# Altium schematic sheet IO.SchDoc(IO)
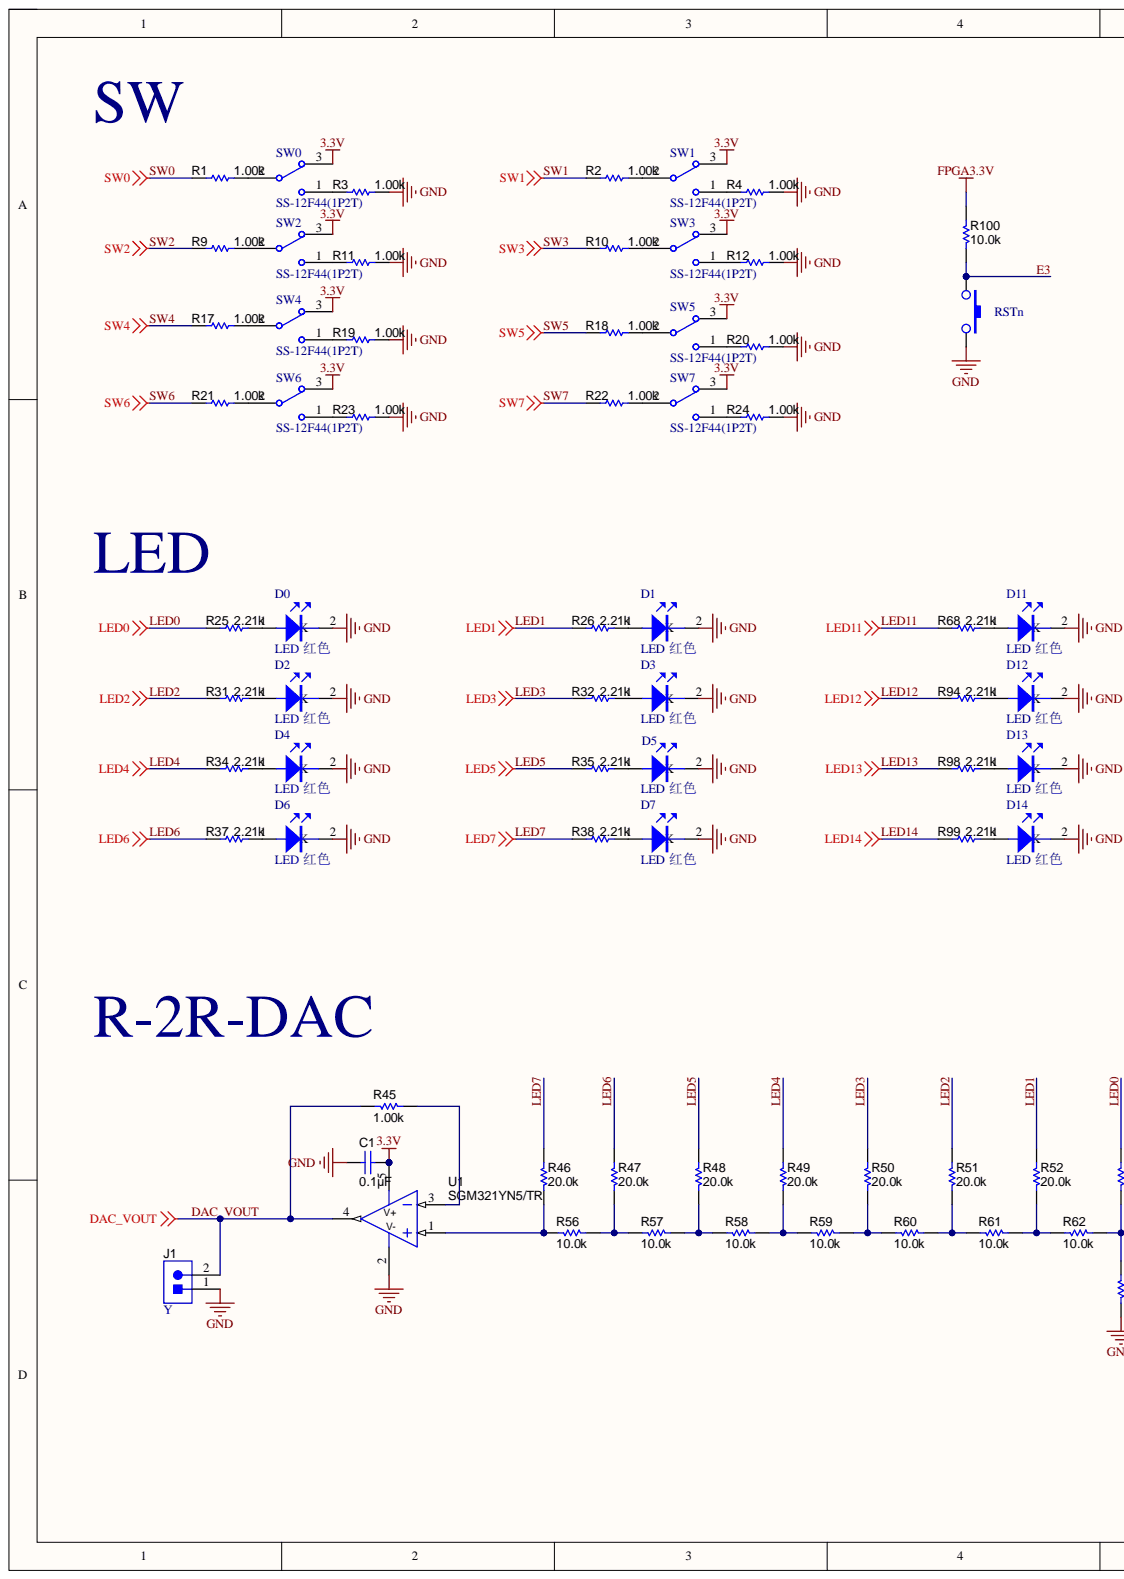
[diagram p0: IO.SchDoc, left]
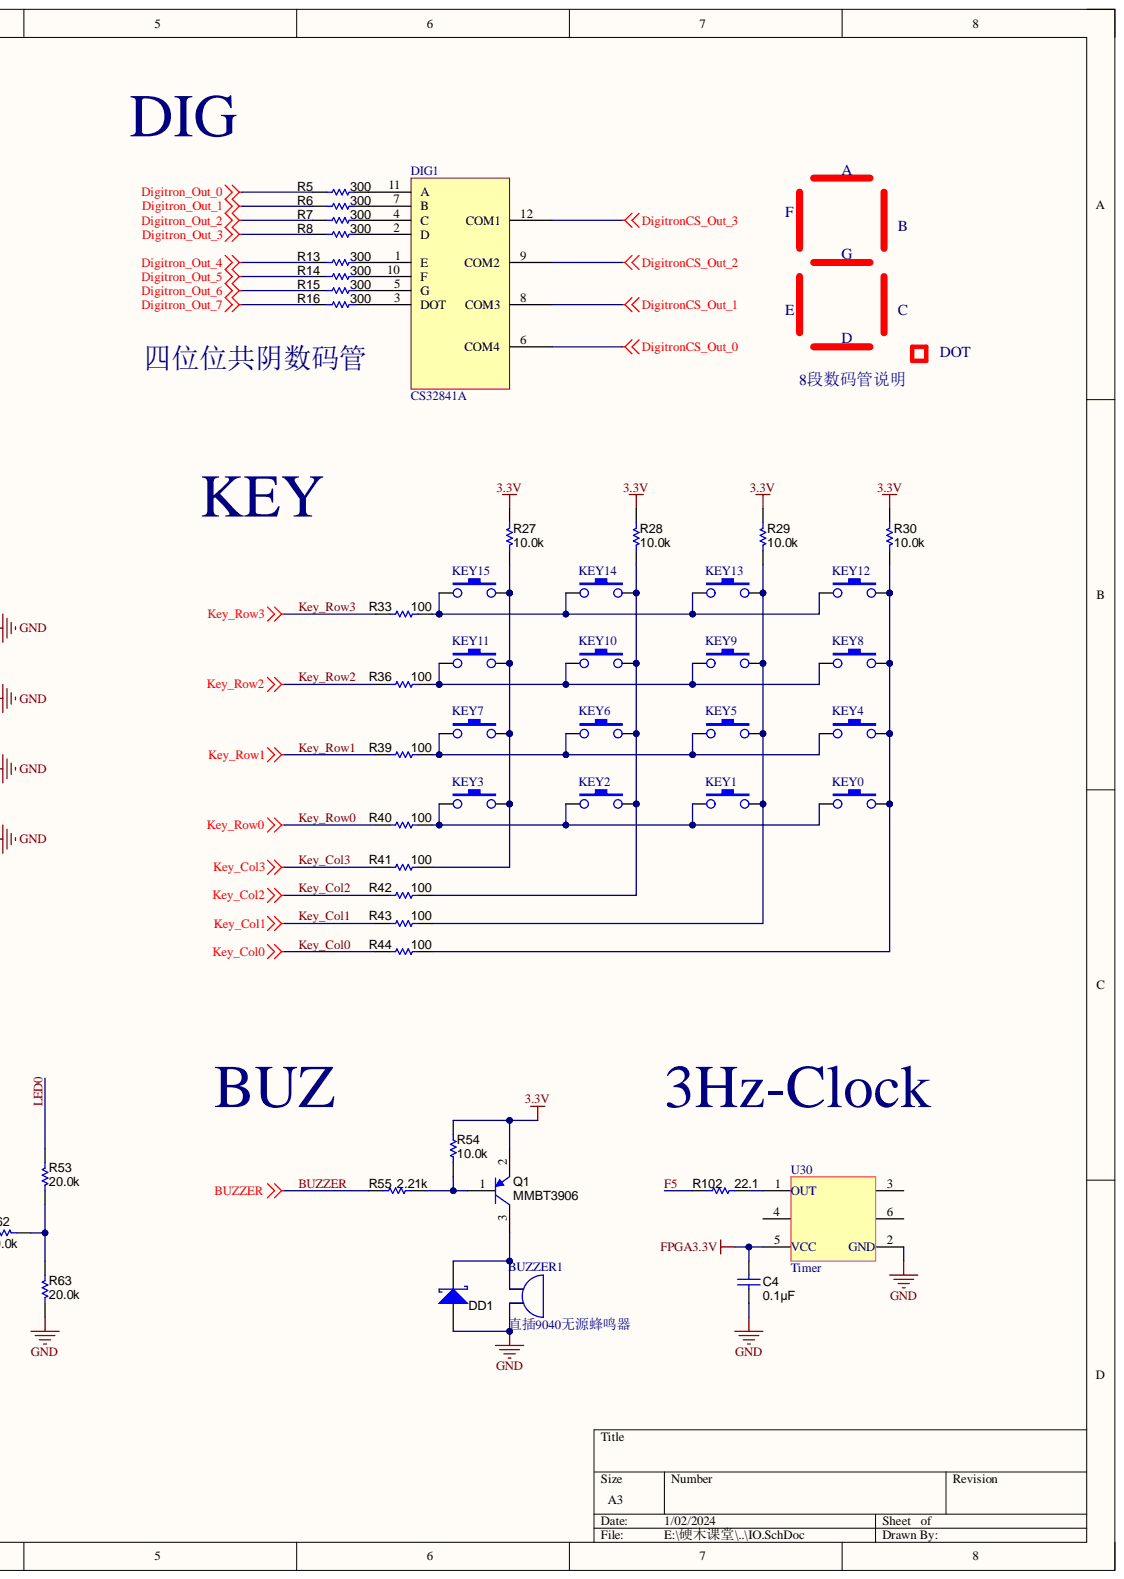
[diagram p1: IO.SchDoc, right]

## Parts
BUZZER1  直插9040无源蜂鸣器  [BUZZER_9*9_4MM]
C1  ValueDisplayed: 0.1µF  [0402]  (hidden comment: C1005X7R1H104M)
C4  ValueDisplayed: 0.1µF  [0402]  (hidden comment: C1005X7R1H104M)
D0  LED 红色  [LED_LTST-C170]
D1  LED 红色  [LED_LTST-C170]
D2  LED 红色  [LED_LTST-C170]
D3  LED 红色  [LED_LTST-C170]
D4  LED 红色  [LED_LTST-C170]
D5  LED 红色  [LED_LTST-C170]
D6  LED 红色  [LED_LTST-C170]
D7  LED 红色  [LED_LTST-C170]
D11  LED 红色  [LED_LTST-C170]
D12  LED 红色  [LED_LTST-C170]
D13  LED 红色  [LED_LTST-C170]
D14  LED 红色  [LED_LTST-C170]
DD1  1N5819  [SOD-123F]  (value not drawn)
DIG1  CS32841A  [CS2845AUR]
J1  Y  [Samtec_HTSW-102-07-G-S]
KEY0  贴片按键  [BUTTOM_T_6*6 *4.3]  (value not drawn)
KEY1  贴片按键  [BUTTOM_T_6*6 *4.3]  (value not drawn)
KEY2  贴片按键  [BUTTOM_T_6*6 *4.3]  (value not drawn)
KEY3  贴片按键  [BUTTOM_T_6*6 *4.3]  (value not drawn)
KEY4  贴片按键  [BUTTOM_T_6*6 *4.3]  (value not drawn)
KEY5  贴片按键  [BUTTOM_T_6*6 *4.3]  (value not drawn)
KEY6  贴片按键  [BUTTOM_T_6*6 *4.3]  (value not drawn)
KEY7  贴片按键  [BUTTOM_T_6*6 *4.3]  (value not drawn)
KEY8  贴片按键  [BUTTOM_T_6*6 *4.3]  (value not drawn)
KEY9  贴片按键  [BUTTOM_T_6*6 *4.3]  (value not drawn)
KEY10  贴片按键  [BUTTOM_T_6*6 *4.3]  (value not drawn)
KEY11  贴片按键  [BUTTOM_T_6*6 *4.3]  (value not drawn)
KEY12  贴片按键  [BUTTOM_T_6*6 *4.3]  (value not drawn)
KEY13  贴片按键  [BUTTOM_T_6*6 *4.3]  (value not drawn)
KEY14  贴片按键  [BUTTOM_T_6*6 *4.3]  (value not drawn)
KEY15  贴片按键  [BUTTOM_T_6*6 *4.3]  (value not drawn)
Q1  MMBT3906  [SOT-23]
R1  value 1.00k  [0402]  (hidden comment: CRCW04021K00FKED)
R2  value 1.00k  [0402]  (hidden comment: CRCW04021K00FKED)
R3  value 1.00k  [0402]  (hidden comment: CRCW04021K00FKED)
R4  value 1.00k  [0402]  (hidden comment: CRCW04021K00FKED)
R5  value 300  [0402]  (hidden comment: RG1005P-301-B-T5)
R6  value 300  [0402]  (hidden comment: RG1005P-301-B-T5)
R7  value 300  [0402]  (hidden comment: RG1005P-301-B-T5)
R8  value 300  [0402]  (hidden comment: RG1005P-301-B-T5)
R9  value 1.00k  [0402]  (hidden comment: CRCW04021K00FKED)
R10  value 1.00k  [0402]  (hidden comment: CRCW04021K00FKED)
R11  value 1.00k  [0402]  (hidden comment: CRCW04021K00FKED)
R12  value 1.00k  [0402]  (hidden comment: CRCW04021K00FKED)
R13  value 300  [0402]  (hidden comment: RG1005P-301-B-T5)
R14  value 300  [0402]  (hidden comment: RG1005P-301-B-T5)
R15  value 300  [0402]  (hidden comment: RG1005P-301-B-T5)
R16  value 300  [0402]  (hidden comment: RG1005P-301-B-T5)
R17  value 1.00k  [0402]  (hidden comment: CRCW04021K00FKED)
R18  value 1.00k  [0402]  (hidden comment: CRCW04021K00FKED)
R19  value 1.00k  [0402]  (hidden comment: CRCW04021K00FKED)
R20  value 1.00k  [0402]  (hidden comment: CRCW04021K00FKED)
R21  value 1.00k  [0402]  (hidden comment: CRCW04021K00FKED)
R22  value 1.00k  [0402]  (hidden comment: CRCW04021K00FKED)
R23  value 1.00k  [0402]  (hidden comment: CRCW04021K00FKED)
R24  value 1.00k  [0402]  (hidden comment: CRCW04021K00FKED)
R25  value 2.21k  [0402]  (hidden comment: CRCW04022K21FKED)
R26  value 2.21k  [0402]  (hidden comment: CRCW04022K21FKED)
R27  value 10.0k  [0402]  (hidden comment: CRCW040210K0FKED)
R28  value 10.0k  [0402]  (hidden comment: CRCW040210K0FKED)
R29  value 10.0k  [0402]  (hidden comment: CRCW040210K0FKED)
R30  value 10.0k  [0402]  (hidden comment: CRCW040210K0FKED)
R31  value 2.21k  [0402]  (hidden comment: CRCW04022K21FKED)
R32  value 2.21k  [0402]  (hidden comment: CRCW04022K21FKED)
R33  value 100  [0402]  (hidden comment: CRCW0402100RFKED)
R34  value 2.21k  [0402]  (hidden comment: CRCW04022K21FKED)
R35  value 2.21k  [0402]  (hidden comment: CRCW04022K21FKED)
R36  value 100  [0402]  (hidden comment: CRCW0402100RFKED)
R37  value 2.21k  [0402]  (hidden comment: CRCW04022K21FKED)
R38  value 2.21k  [0402]  (hidden comment: CRCW04022K21FKED)
R39  value 100  [0402]  (hidden comment: CRCW0402100RFKED)
R40  value 100  [0402]  (hidden comment: CRCW0402100RFKED)
R41  value 100  [0402]  (hidden comment: CRCW0402100RFKED)
R42  value 100  [0402]  (hidden comment: CRCW0402100RFKED)
R43  value 100  [0402]  (hidden comment: CRCW0402100RFKED)
R44  value 100  [0402]  (hidden comment: CRCW0402100RFKED)
R45  value 1.00k  [0402]  (hidden comment: CRCW04021K00FKED)
R46  value 20.0k  [0402]  (hidden comment: CRCW040220K0FKED)
R47  value 20.0k  [0402]  (hidden comment: CRCW040220K0FKED)
R48  value 20.0k  [0402]  (hidden comment: CRCW040220K0FKED)
R49  value 20.0k  [0402]  (hidden comment: CRCW040220K0FKED)
R50  value 20.0k  [0402]  (hidden comment: CRCW040220K0FKED)
R51  value 20.0k  [0402]  (hidden comment: CRCW040220K0FKED)
R52  value 20.0k  [0402]  (hidden comment: CRCW040220K0FKED)
R53  value 20.0k  [0402]  (hidden comment: CRCW040220K0FKED)
R54  value 10.0k  [0402]  (hidden comment: CRCW040210K0FKED)
R55  value 2.21k  [0402]  (hidden comment: CRCW04022K21FKED)
R56  value 10.0k  [0402]  (hidden comment: CRCW040210K0FKED)
R57  value 10.0k  [0402]  (hidden comment: CRCW040210K0FKED)
R58  value 10.0k  [0402]  (hidden comment: CRCW040210K0FKED)
R59  value 10.0k  [0402]  (hidden comment: CRCW040210K0FKED)
R60  value 10.0k  [0402]  (hidden comment: CRCW040210K0FKED)
R61  value 10.0k  [0402]  (hidden comment: CRCW040210K0FKED)
R62  value 10.0k  [0402]  (hidden comment: CRCW040210K0FKED)
R63  value 20.0k  [0402]  (hidden comment: CRCW040220K0FKED)
R68  value 2.21k  [0402]  (hidden comment: CRCW04022K21FKED)
R94  value 2.21k  [0402]  (hidden comment: CRCW04022K21FKED)
R98  value 2.21k  [0402]  (hidden comment: CRCW04022K21FKED)
R99  value 2.21k  [0402]  (hidden comment: CRCW04022K21FKED)
R100  value 10.0k  [0402]  (hidden comment: CRCW040210K0FKED)
R102  value 22.1  Res_ohm: 22.1  [0402]  (hidden comment: CRCW040222R1FKED)
RSTn  贴片按键  [BUTTOM_T_2]  (value not drawn)
SW0  SS-12F44(1P2T)  [SS-12F44(1P2T)]
SW1  SS-12F44(1P2T)  [SS-12F44(1P2T)]
SW2  SS-12F44(1P2T)  [SS-12F44(1P2T)]
SW3  SS-12F44(1P2T)  [SS-12F44(1P2T)]
SW4  SS-12F44(1P2T)  [SS-12F44(1P2T)]
SW5  SS-12F44(1P2T)  [SS-12F44(1P2T)]
SW6  SS-12F44(1P2T)  [SS-12F44(1P2T)]
SW7  SS-12F44(1P2T)  [SS-12F44(1P2T)]
U1  SGM321YN5/TR  [DBV0005A_N]
U30  Timer  [DBV0006A_N]

## Nets
3.3V: C1.1 Q1.2 R27.2 R28.2 R29.2 R30.2 R54.2 SW0.3 SW1.3 SW2.3 SW3.3 SW4.3 SW5.3 SW6.3 SW7.3 U1.5(V+)
Digitron_Out_0: R5.2
Digitron_Out_1: R6.2
Digitron_Out_2: R7.2
Digitron_Out_3: R8.2
Digitron_Out_4: R13.2
Digitron_Out_5: R14.2
Digitron_Out_6: R15.2
Digitron_Out_7: R16.2
DigitronCS_Out_0: DIG1.6(COM4)
DigitronCS_Out_1: DIG1.8(COM3)
DigitronCS_Out_2: DIG1.9(COM2)
DigitronCS_Out_3: DIG1.12(COM1)
FPGA3.3V: C4.2 R100.2 U30.5(VCC)
GND: BUZZER1.2 C1.2 C4.1 D0.2 D1.2 D2.2 D3.2 D4.2 D5.2 D6.2 D7.2 D11.2 D12.2 D13.2 D14.2 DD1.2 J1.1 R3.1 R4.1 R11.1 R12.1 R19.1 R20.1 R23.1 R24.1 R63.2 RSTn.1 U1.2 U30.2(GND)
BUZZER: R55.2
DAC_VOUT: J1.2 R45.1 U1.4
E3: R100.1 RSTn.2
F5: R102.2
Key_Col0: R44.2
Key_Col1: R43.2
Key_Col2: R42.2
Key_Col3: R41.2
Key_Row0: R40.2
Key_Row1: R39.2
Key_Row2: R36.2
Key_Row3: R33.2
LED0: R25.2 R53.1
LED1: R26.2 R52.1
LED2: R31.2 R51.1
LED3: R32.2 R50.1
LED4: R34.2 R49.1
LED5: R35.2 R48.1
LED6: R37.2 R47.1
LED7: R38.2 R46.1
LED11: R68.2
LED12: R94.2
LED13: R98.2
LED14: R99.2
SW0: R1.2
SW1: R2.2
SW2: R9.2
SW3: R10.2
SW4: R17.2
SW5: R18.2
SW6: R21.2
SW7: R22.2
NetBUZZER1_1: BUZZER1.1 DD1.1 Q1.3
NetD0_1: D0.1 R25.1
NetD1_1: D1.1 R26.1
NetD2_1: D2.1 R31.1
NetD3_1: D3.1 R32.1
NetD4_1: D4.1 R34.1
NetD5_1: D5.1 R35.1
NetD6_1: D6.1 R37.1
NetD7_1: D7.1 R38.1
NetD11_1: D11.1 R68.1
NetD12_1: D12.1 R94.1
NetD13_1: D13.1 R98.1
NetD14_1: D14.1 R99.1
NetDIG1_1: DIG1.1(E) R13.1
NetDIG1_2: DIG1.2(D) R8.1
NetDIG1_3: DIG1.3(DOT) R16.1
NetDIG1_4: DIG1.4(C) R7.1
NetDIG1_5: DIG1.5(G) R15.1
NetDIG1_7: DIG1.7(B) R6.1
NetDIG1_10: DIG1.10(F) R14.1
NetDIG1_11: DIG1.11(A) R5.1
NetKEY0_1: KEY0.1 KEY1.1 KEY2.1 KEY3.1 R40.1
NetKEY0_2: KEY0.2 KEY4.2 KEY8.2 KEY12.2 R30.1 R44.1
NetKEY1_2: KEY1.2 KEY5.2 KEY9.2 KEY13.2 R29.1 R43.1
NetKEY2_2: KEY2.2 KEY6.2 KEY10.2 KEY14.2 R28.1 R42.1
NetKEY3_2: KEY3.2 KEY7.2 KEY11.2 KEY15.2 R27.1 R41.1
NetKEY4_1: KEY4.1 KEY5.1 KEY6.1 KEY7.1 R39.1
NetKEY8_1: KEY8.1 KEY9.1 KEY10.1 KEY11.1 R36.1
NetKEY12_1: KEY12.1 KEY13.1 KEY14.1 KEY15.1 R33.1
NetQ1_1: Q1.1 R54.1 R55.1
NetR1_1: R1.1 SW0.2
NetR2_1: R2.1 SW1.2
NetR3_2: R3.2 SW0.1
NetR4_2: R4.2 SW1.1
NetR9_1: R9.1 SW2.2
NetR10_1: R10.1 SW3.2
NetR11_2: R11.2 SW2.1
NetR12_2: R12.2 SW3.1
NetR17_1: R17.1 SW4.2
NetR18_1: R18.1 SW5.2
NetR19_2: R19.2 SW4.1
NetR20_2: R20.2 SW5.1
NetR21_1: R21.1 SW6.2
NetR22_1: R22.1 SW7.2
NetR23_2: R23.2 SW6.1
NetR24_2: R24.2 SW7.1
NetR45_2: R45.2 U1.3
NetR46_2: R46.2 R56.1 U1.1
NetR47_2: R47.2 R56.2 R57.1
NetR48_2: R48.2 R57.2 R58.1
NetR49_2: R49.2 R58.2 R59.1
NetR50_2: R50.2 R59.2 R60.1
NetR51_2: R51.2 R60.2 R61.1
NetR52_2: R52.2 R61.2 R62.1
NetR53_2: R53.2 R62.2 R63.1
NetR102_1: R102.1 U30.1(OUT)

Not connected: U30 3(IO2) 4(IO3) 6(IO4)

## Library data of the parts
BUZZER1 = BUZZER_EFBAA14D001: Buzzer, 74 dB min, 25V p-p, 8500pF @ 1kHz，直插9040无源蜂鸣器; Manufacturer: Panasonic; Area: 264,208; Value: {Value}; Component Kind: Standard; ComponentHeight: 0.276 inch; ComponentLink1Description: AEO Datasheet Link; PackageReference: 0.550 DIA.; PartNumber: 直插9040无源蜂鸣器; SymbolVersion: 07/31/2009 12:17:27; Library Name: PADSlegacy_Passive.IntLib
C1 C4 = C1005X7R1H104M: CAP, CERM, 0.1 µF, 50 V, +/- 20%, X7R, 0402; Manufacturer: TDK; Cap_F: 0.0000001; CompType: Capacitor; Polarized: N; TaMax_C: 125; TaMin_C: -55; Tolerance_%: 20; U/M: EA; Value: 0.1uF; Value1: Unknown; Value2: 50V; VDC_V: 50; Cap_Case: 0402; Component Kind: Standard; ComponentLink1Description: Datasheet link; LatestRevisionDate: 2015-05-02; Library Name: Capacitor_Ceramic.IntLib; MountType: SMT; PackageReference: 0402; PartNumber: C1005X7R1H104M; Published: 2008-06-19; RoHS: Y; Signal Integrity: Cap; Simulation: Cap; Supplier 1: Digi-Key; Supplier 2: Farnell; Supplier Part Number 1: 445-5933-1-ND; Supplier Part Number 2: 1907278; SymbolVersion: 2008-07-09; Technology: Ceramic; TempCo: X7R; Publisher: Texas Instruments
D0-D7 D11-D14 = LED: LED 发光二极管 0805; Component Kind: Standard; Library Name: WK_PCB.SchLib
DD1 = PMEG2010AEH,115: Diode, Schottky, 20 V, 1 A, SOD-123F; Manufacturer: NXP Semiconductor; IGNORE: N; Io_A: 1; TJmax_C: 150; U/M: EA; Value: 20V; Value1: 1A; Value2: 0.43V; Vf_V: 0.43; Vr_V: 20; Component Kind: Standard; ComponentLink1Description: Datasheet link; ComponentLink1URL: http://www.nxp.com/documents/data_sheet/PMEG2010AEH_PMEG2010AET.pdf; CompType: Diode; LatestRevisionDate: 2015-04-30; Library Name: Diode.IntLib; MountType: SMT; PackageReference: SOD-123F; PartNumber: 1N5819; Published: 2008-06-26; RoHS: Y; Signal Integrity: Diode; Supplier 1: Digi-Key; Supplier 2: Mouser; Supplier 3: Farnell; Supplier Part Number 1: 568-4112-1-ND; Supplier Part Number 2: 771-PMEG2010AEH-T/R; Supplier Part Number 3: 2371105; SymbolVersion: 2008-12-08; Type: Schottky; Publisher: Texas Instruments
DIG1 = 4LED-ANODE: 4位共阴数码管，红色; Component Kind: Standard
J1 = HTSW-102-07-G-S: Header, 100mil, 2x1, Gold, TH; Manufacturer: Samtec; PartNumber: 1x2直插圆孔，2.54mm; SymbolCreator: a0412235; U/M: EA; ClassName: Header; Component Kind: Standard; ComponentLink1Description: Datasheet Link; ComponentLink1URL: http://www.samtec.com/ftppub/pdf/TSW_TH.PDF; CompType: Header; IGNORE: Y; LatestRevisionDate: 2014-11-22; Library Name: Connectors.IntLib; MountType: TH; PackageReference: Header, 100mil, 2x1, TH; RoHS: Y; Supplier 1: Digi-Key; Supplier Part Number 1: SAM8736-ND; SymbolVersion: 2013-10-31; Publisher: Texas Instruments
KEY0-KEY15 RSTn = SW-PB: 米黄色圆形按键6*6*3.4; Component Kind: Standard
Q1 = MMBT3906, Fairchild Semiconductor: Transistor, PNP, 40 V, 0.2 A, SOT-23; CompType: BJT; HfeMax: 300; IcRated_A: 0.2; NPN: 0; PNP: 1; TaMax_C: 150; TaMin_C: -55; U/M: EA; Value: 40 V; Value1: 0.2 A; Vceo_V: 40; Vcesat1_V: 0.25; Component Kind: Standard; ComponentLink1Description: Datasheet link; ComponentLink1URL: http://www.fairchildsemi.com/ds/MM/MMBT3906.pdf; LatestRevisionDate: 2015-04-27; Library Name: Bipolar_Transistor.IntLib; Manufacturer: Fairchild Semiconductor; MountType: SMT; PackageReference: SOT-23; PartNumber: MMBT3906; Published: 2008-07-31; RoHS: Y; Simulation: MMBT3906; Supplier 1: Digi-Key; Supplier 2: Farnell; Supplier Part Number 1: MMBT3906FSCT-ND; Supplier Part Number 2: 9846832; SymbolVersion: 2008-07-31; Value2: 300; Publisher: Texas Instruments
R1-R4 R9-R12 R17-R24 R45 = CRCW04021K00FKED: RES, 1.00 k, 1%, 0.063 W, 0402; Manufacturer: Vishay-Dale; CompType: Resistor; EIA_Code: E96; Power_W: 0.063; Res_ohm: 1000; TempCo: 100 ppm/C; Tolerance_%: 1; U/M: EA; Value2: 0.063W; Value_Code: 1k00; VDC_V: 50; Component Kind: Standard; ComponentLink1Description: Datasheet link; ComponentLink1URL: http://www.vishay.com/docs/20035/dcrcwe3.pdf; LatestRevisionDate: 2015-05-01; Library Name: Resistor_0402.IntLib; MountType: SMT; PackageReference: 0402; PartNumber: CRCW04021K00FKED; Published: 2008-06-26; RoHS: Y; Signal Integrity: Resistor; Simulation: Resistor; Supplier 1: Digi-Key; Supplier 2: Mouser; Supplier 3: Farnell; Supplier Part Number 1: 541-1.00KLCT-ND; Supplier Part Number 2: 71-CRCW0402-1.0K-E3; Supplier Part Number 3: 1469662; SymbolVersion: 2009-05-29; Technology: Thick Film; Value1: 1%; ValueDisplayed: 1.00k; Publisher: Texas Instruments
R5-R8 R13-R16 = RG1005P-301-B-T5: RES, 300, 0.1%, 0.063 W, 0402; Manufacturer: Susumu Co Ltd; CompType: Resistor; Power_W: 0.063; TempCo: 25 ppm/C; Tolerance_%: 0.1; U/M: EA; Value2: 0.063W; Value_Code: 300R; VDC_V: 50; Component Kind: Standard; ComponentLink1Description: Datasheet link; ComponentLink1URL: http://www.susumu-usa.com/pdf/RG_Data_Sheet.pdf; LatestRevisionDate: 2015-05-01; Library Name: Resistor_0402.IntLib; MountType: SMT; PackageReference: 0402; PartNumber: RG1005P-301-B-T5; Published: 2008-06-26; Res_ohm: 300; RoHS: Y; Signal Integrity: Resistor; Simulation: Resistor; Supplier 1: Digi-Key; Supplier 2: Mouser; Supplier Part Number 1: RG10P300BCT-ND; Supplier Part Number 2: 754-RG1005P-301-B-T5; SymbolVersion: 2009-05-29; Technology: Thin Film; Value1: 0.1%; ValueDisplayed: 300; Publisher: Texas Instruments
R25 R26 R31 R32 R34 R35 R37 R38 R55 R68 R94 R98 R99 = CRCW04022K21FKED: RES, 2.21 k, 1%, 0.063 W, 0402; Manufacturer: Vishay-Dale; CompType: Resistor; EIA_Code: E96; Power_W: 0.063; Res_ohm: 2210; TempCo: 100 ppm/C; Tolerance_%: 1; U/M: EA; Value2: 0.063W; Value_Code: 2k21; VDC_V: 50; Component Kind: Standard; ComponentLink1Description: Datasheet link; ComponentLink1URL: http://www.vishay.com/docs/20035/dcrcwe3.pdf; LatestRevisionDate: 2015-05-01; Library Name: Resistor_0402.IntLib; MountType: SMT; PackageReference: 0402; PartNumber: CRCW04022K21FKED; Published: 2008-06-26; RoHS: Y; Signal Integrity: Resistor; Simulation: Resistor; Supplier 1: Digi-Key; Supplier 2: Mouser; Supplier 3: Farnell; Supplier Part Number 1: 541-2.21KLCT-ND; Supplier Part Number 2: 71-CRCW0402-2.21K-E3; Supplier Part Number 3: 1469689; SymbolVersion: 2009-05-29; Technology: Thick Film; Value1: 1%; ValueDisplayed: 2.21k; Publisher: Texas Instruments
R27-R30 R54 R56-R62 R100 = CRCW040210K0FKED: RES, 10.0 k, 1%, 0.063 W, 0402; Manufacturer: Vishay-Dale; CompType: Resistor; EIA_Code: E96; Power_W: 0.063; Res_ohm: 10000; TempCo: 100 ppm/C; Tolerance_%: 1; U/M: EA; Value2: 0.063W; Value_Code: 10k0; VDC_V: 50; Component Kind: Standard; ComponentLink1Description: Datasheet link; ComponentLink1URL: http://www.vishay.com/docs/20035/dcrcwe3.pdf; LatestRevisionDate: 2015-05-01; Library Name: Resistor_0402.IntLib; MountType: SMT; PackageReference: 0402; PartNumber: CRCW040210K0FKED; Published: 2008-06-26; RoHS: Y; Signal Integrity: Resistor; Simulation: Resistor; Supplier 1: Digi-Key; Supplier 2: Mouser; Supplier 3: Farnell; Supplier Part Number 1: 541-10.0KLCT-ND; Supplier Part Number 2: 71-CRCW0402-10K-E3; Supplier Part Number 3: 1469669; SymbolVersion: 2009-05-29; Technology: Thick Film; Value1: 1%; ValueDisplayed: 10.0k; Publisher: Texas Instruments
R33 R36 R39-R44 = CRCW0402100RFKED: RES, 100, 1%, 0.063 W, 0402; Manufacturer: Vishay-Dale; CompType: Resistor; EIA_Code: E96; Power_W: 0.063; TempCo: 100 ppm/C; Tolerance_%: 1; U/M: EA; Value2: 0.063W; Value_Code: 100R; VDC_V: 50; Component Kind: Standard; ComponentLink1Description: Datasheet link; ComponentLink1URL: http://www.vishay.com/docs/20035/dcrcwe3.pdf; LatestRevisionDate: 2015-05-01; Library Name: Resistor_0402.IntLib; MountType: SMT; PackageReference: 0402; PartNumber: CRCW0402100RFKED; Published: 2008-06-26; Res_ohm: 100; RoHS: Y; Signal Integrity: Resistor; Simulation: Resistor; Supplier 1: Digi-Key; Supplier 2: Mouser; Supplier 3: Farnell; Supplier Part Number 1: 541-100LCT-ND; Supplier Part Number 2: 71-CRCW0402-100-E3; Supplier Part Number 3: 1469672; SymbolVersion: 2009-05-29; Technology: Thick Film; Value1: 1%; ValueDisplayed: 100; Publisher: Texas Instruments
R46-R53 R63 = CRCW040220K0FKED: RES, 20.0 k, 1%, 0.063 W, 0402; Manufacturer: Vishay-Dale; CompType: Resistor; EIA_Code: E96; Power_W: 0.063; Res_ohm: 20000; TempCo: 100 ppm/C; Tolerance_%: 1; U/M: EA; Value2: 0.063W; Value_Code: 20k0; VDC_V: 50; Component Kind: Standard; ComponentLink1Description: Datasheet link; ComponentLink1URL: http://www.vishay.com/docs/20035/dcrcwe3.pdf; LatestRevisionDate: 2015-05-01; Library Name: Resistor_0402.IntLib; MountType: SMT; PackageReference: 0402; PartNumber: CRCW040220K0FKED; Published: 2008-06-26; RoHS: Y; Signal Integrity: Resistor; Simulation: Resistor; Supplier 1: Digi-Key; Supplier 2: Mouser; Supplier 3: Farnell; Supplier Part Number 1: 541-20.0KLCT-ND; Supplier Part Number 2: 71-CRCW0402-20K-E3; Supplier Part Number 3: 1469693; SymbolVersion: 2009-05-29; Technology: Thick Film; Value1: 1%; ValueDisplayed: 20.0k; Publisher: Texas Instruments
R102 = CRCW040222R1FKED: RES, 22.1, 1%, 0.063 W, 0402; Manufacturer: Vishay-Dale; CompType: Resistor; EIA_Code: E96; Power_W: 0.063; TempCo: 100 ppm/C; Tolerance_%: 1; U/M: EA; Value2: 0.063W; Value_Code: 22R1; VDC_V: 50; Component Kind: Standard; ComponentLink1Description: Datasheet link; ComponentLink1URL: http://www.vishay.com/docs/20035/dcrcwe3.pdf; LatestRevisionDate: 2015-05-01; Library Name: Resistor_0402.IntLib; MountType: SMT; PackageReference: 0402; PartNumber: CRCW040222R1FKED; Published: 2008-06-26; RoHS: Y; Signal Integrity: Resistor; Simulation: Resistor; Supplier 1: Digi-Key; Supplier 2: Mouser; Supplier 3: Farnell; Supplier Part Number 1: 541-22.1LCT-ND; Supplier Part Number 2: 71-CRCW0402-22.1-E3; Supplier Part Number 3: 1469698; SymbolVersion: 2009-05-29; Technology: Thick Film; Value1: 1%; ValueDisplayed: 22.1; Publisher: Texas Instruments
SW0-SW7 = SW-SPDT: SPDT Subminiature Toggle Switch, Right Angle Mounting, Vertical Actuation; Component Kind: Standard; ComponentLink1Description: Manufacturer Link; ComponentLink1URL: http://www.apem.com; DatasheetVersion: 05-Dec-2000; LatestRevisionDate: 17-Dec-2003; LatestRevisionNote: Schematic revised, PCB Footprint replaced.; Library Name: Miscellaneous Devices.IntLib; PackageDescription: Switch; 4 Leads; PackageReference: TL36WW15050; Published: 24-Mar-1999; Publisher: Altium Limited
U1 = LM321MF: Low Power Single Op Amp, DBV0005A; Alternate Manufacturer: Texas Instruments; CompType: OpAmp IC; SymbolCreator: a0411595; Component Kind: Standard; ComponentLink1Description: LM321 Datasheet; ComponentLink1URL: http://www.ti.com/lit/gpn/LM321; ComponentLink2Description: LM321 Web Page; ComponentLink2URL: http://www.ti.com/product/LM321; ComponentLink3Description: SOT Package Web Page; ComponentLink3URL: http://www.ti.com/sc/docs/psheets/type/sot.html; LatestRevisionDate: 2015-02-03; Library Name: NSC Amplifier.IntLib; Manufacturer: Texas Instruments; MountType: SMT; PackageReference: DBV0005A; PartNumber: SGM321YN5/TR; Simulation: LM324/NS; SymbolVersion: 2015-01-06; Publisher: Texas Instruments
U30 = TPD4E001DBVR: Timer_3Hz; Component Kind: Standard; Library Name: TI Interface ESD EMI Protection.IntLib
